# Altium schematic sheet motherboard-axp2101.SchDoc
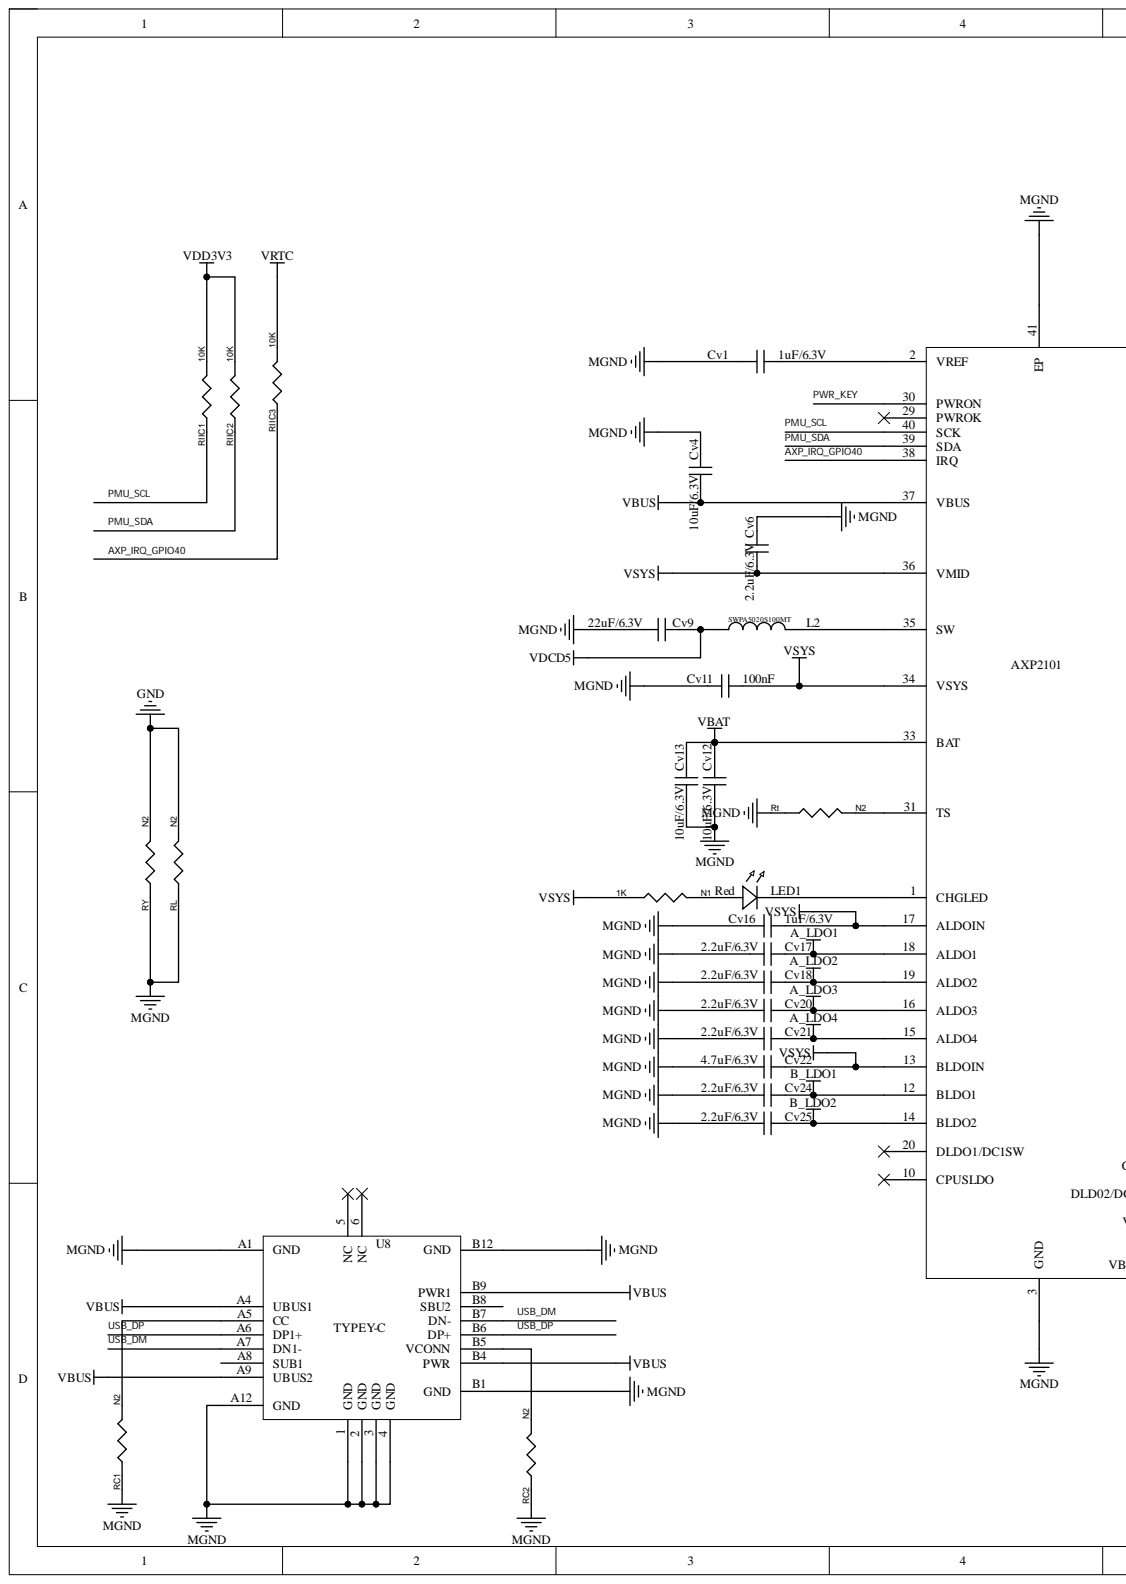
[diagram p0: motherboard-axp2101.SchDoc, left]
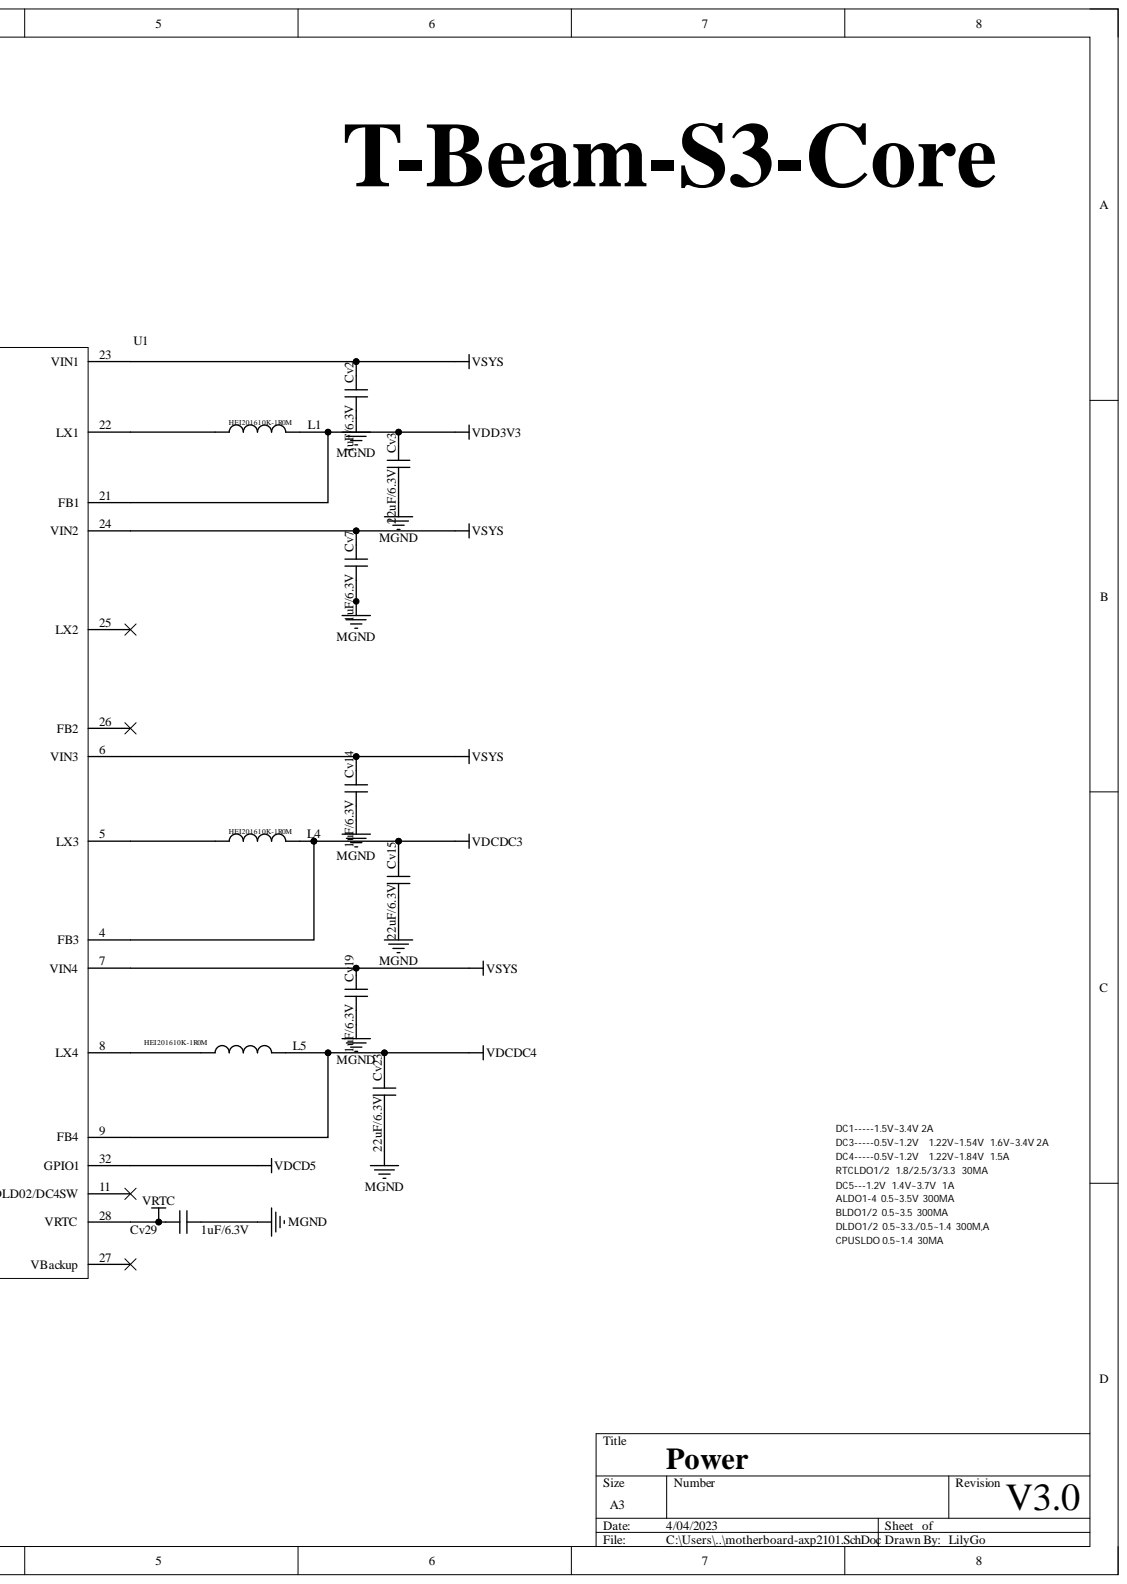
[diagram p1: motherboard-axp2101.SchDoc, right]

## Parts
Cv1  1uF/6.3V  [LC-0402_C]
Cv2  1uF/6.3V  [LC-0402_C]
Cv3  22uF/6.3V  [LC-0805_C]
Cv4  10uF/6.3V  [LC-0603_C]
Cv6  2.2uF/6.3V  [LC-0402_C]
Cv7  1uF/6.3V  [LC-0402_C]
Cv9  22uF/6.3V  [LC-0805_C]
Cv11  100nF  [LC-0402_C]
Cv12  10uF/6.3V  [LC-0402_C]
Cv13  10uF/6.3V  [LC-0402_C]
Cv14  1uF/6.3V  [LC-0402_C]
Cv15  22uF/6.3V  [LC-0805_C]
Cv16  1uF/6.3V  [LC-0402_C]
Cv17  2.2uF/6.3V  [LC-0402_C]
Cv18  2.2uF/6.3V  [LC-0402_C]
Cv19  1uF/6.3V  [LC-0402_C]
Cv20  2.2uF/6.3V  [LC-0402_C]
Cv21  2.2uF/6.3V  [LC-0402_C]
Cv22  4.7uF/6.3V  [LC-0402_C]
Cv23  22uF/6.3V  [LC-0805_C]
Cv24  2.2uF/6.3V  [LC-0402_C]
Cv25  2.2uF/6.3V  [LC-0402_C]
Cv29  1uF/6.3V  [LC-0402_C]
L1  HEI201610K-1R0M  [L0806]
L2  SWPA5020S100MT  [L0806]
L4  HEI201610K-1R0M  [L0806]
L5  HEI201610K-1R0M  [L0806]
LED1  Red  [LC-0402_R]
N1  1K  [LC-0402_R]
RC1  N2  [LC-0402_R]
RC2  N2  [LC-0402_R]
RIIC1  10K  [LC-0402_R]
RIIC2  10K  [LC-0402_R]
RIIC3  10K  [LC-0402_R]
RL  N2  [LC-0805_C]
Rt  N2  [LC-0402_R]
RY  N2  [LC-0805_C]
U1  AXP2101  [QFN-40]
U8  TYPEY-C  [TYPE-C3.0]

## Nets
A_LDO1: Cv17.2 U1.18(ALDO1)
A_LDO2: Cv18.2 U1.19(ALDO2)
A_LDO3: Cv20.2 U1.16(ALDO3)
A_LDO4: Cv21.2 U1.15(ALDO4)
B_LDO1: Cv24.2 U1.12(BLDO1)
B_LDO2: Cv25.2 U1.14(BLDO2)
GND: RL.2 RY.2
MGND: Cv1.1 Cv2.1 Cv3.1 Cv4.1 Cv6.2 Cv7.1 Cv9.2 Cv11.1 Cv12.1 Cv13.1 Cv14.1 Cv15.1 Cv16.1 Cv17.1 Cv18.1 Cv19.1 Cv20.1 Cv21.1 Cv22.1 Cv23.1 Cv24.1 Cv25.1 Cv29.2 RC1.1 RC2.1 RL.1 Rt.1 RY.1 U1.3(GND) U1.41(EP) U8.1(GND) U8.2(GND) U8.3(GND) U8.4(GND) U8.A1(GND) U8.A12(GND) U8.B1(GND) U8.B12(GND)
VBAT: Cv12.2 Cv13.2 U1.33(BAT)
VBUS: Cv4.2 U1.37(VBUS) U8.A4(UBUS1) U8.A9(UBUS2) U8.B4(PWR) U8.B9(PWR1)
VDCD5: Cv9.1 L2.1 U1.32(GPIO1)
VDCDC3: Cv15.2 L4.2 U1.4(FB3)
VDCDC4: Cv23.2 L5.2 U1.9(FB4)
VDD3V3: Cv3.2 L1.2 RIIC1.2 RIIC2.2 U1.21(FB1)
VRTC: Cv29.1 RIIC3.2 U1.28(VRTC)
VSYS: Cv2.2 Cv6.1 Cv7.2 Cv11.2 Cv14.2 Cv16.2 Cv19.2 Cv22.2 N1.1 U1.6(VIN3) U1.7(VIN4) U1.13(BLDOIN) U1.17(ALDOIN) U1.23(VIN1) U1.24(VIN2) U1.34(VSYS) U1.36(VMID)
AXP_IRQ_GPIO40: RIIC3.1 U1.38(IRQ)
PMU_SCL: RIIC1.1 U1.40(SCK)
PMU_SDA: RIIC2.1 U1.39(SDA)
PWR_KEY: U1.30(PWRON)
USB_DM: U8.A7(DN1-) U8.B7(DN-)
USB_DP: U8.A6(DP1+) U8.B6(DP+)
NetCv1_2: Cv1.2 U1.2(VREF)
NetL1_1: L1.1 U1.22(LX1)
NetL2_2: L2.2 U1.35(SW)
NetL4_1: L4.1 U1.5(LX3)
NetL5_1: L5.1 U1.8(LX4)
NetLED1_1: LED1.1 N1.2
NetLED1_2: LED1.2 U1.1(CHGLED)
NetRC1_2: RC1.2 U8.A5(CC)
NetRC2_2: RC2.2 U8.B5(VCONN)
NetRt_2: Rt.2 U1.31(TS)
ALDO1-4  0.5~3.5V  300MA:
BLDO1/2  0.5~3.5  300MA:
CPUSLDO 0.5~1.4  30MA:
DC1-----1.5V~3.4V  2A:
DC3-----0.5V~1.2V     1.22V~1.54V   1.6V~3.4V 2A:
DC4-----0.5V~1.2V     1.22V~1.84V   1.5A:
DC5---1.2V   1.4V~3.7V   1A:
DLDO1/2  0.5~3.3./0.5~1.4  300M,A:
RTCLDO1/2   1.8/2.5/3/3.3   30MA:

Not connected: U1 10(CPUSLDO) 11(DLD02/DC4SW) 20(DLDO1/DC1SW) 25(LX2) 26(FB2) 27(VBackup) 29(PWROK); U8 5(NC) 6(NC) A8(SUB1) B8(SBU2)

## Library data of the parts
Cv1-Cv4 Cv6 Cv7 Cv9 Cv11-Cv25 Cv29 = C: Component Kind: Standard; Library Name: 自建元件库.SCHLIB
L1 L2 L4 L5 = INDUCTOR: Power Inductor, 4.7uH, 0.7A, 260mR DCR; Manufacturer: Taiyo Yuden; Manufacturer Part Number: CBC2518T4R7M; Part Creator: JG; XMOS Part Number: E-09-0011; Approved: NO; Approx Volume Cost ($): 0.07; DEVICE: L; FORWARD_PCB: 1; LEVEL: STD; Max DC Resistance: 0.26R; Rated Current (Saturation): 0.68A; Rated Current (Temp Rise): 0.92A; Tolerance (%): 20; VALUE: 2.0nH; Component Kind: Standard; Datasheet: http://www.yuden.co.jp/us/product/pdf/ecb2_e.pdf; Digikey Link: http://search.digikey.com/scripts/DkSearch/dksus.dll?lang=en&site=US&keywords=CBC2518T4R7M; Library Name: MySchlib.SchLib; Max Height (mm): 2
LED1 = LED: Component Kind: Standard; Library Name: MyLibrary.IntLib
N1 RC1 RC2 RIIC1-RIIC3 RL Rt RY = R: Component Kind: Standard; Library Name: 光学蓝牙.SCHLIB
U1 = AXP2101: Component Kind: Standard; Library Name: 885阿里云PCB_Project1.SCHLIB
U8 = TYPEY-C: Component Kind: Standard; Library Name: 885阿里云PCB_Project1.SCHLIB
